# Altium schematic sheet [9] I2C.SchDoc("[9] I2C")
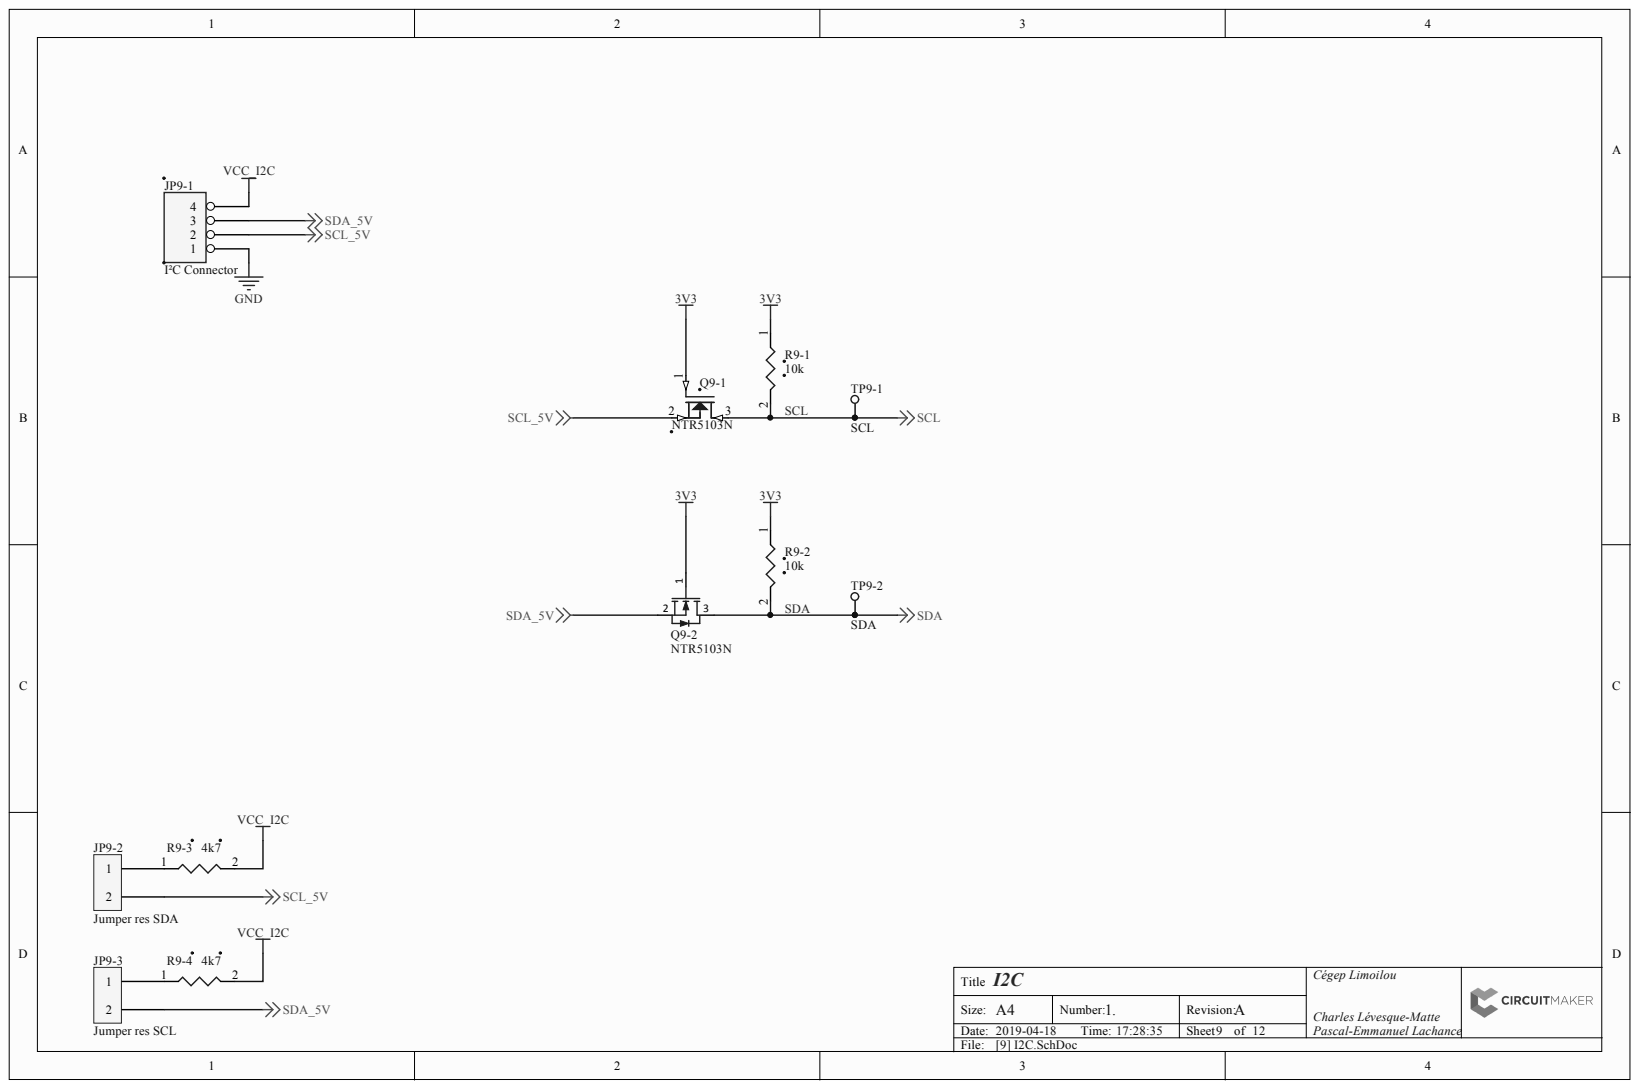
[diagram p0: [9] I2C.SchDoc]

## Parts
JP9-1  I²C Connector  [PCB-kfkyk8r8t2q4xz9myqo9-1]
JP9-2  Jumper res SDA  [PCB-f5rpw3ur0eefzv9pxaxf-1]
JP9-3  Jumper res SCL  [PCB-f5rpw3ur0eefzv9pxaxf-1]
Q9-1  NTR5103N  [PCB-zphymqsti4y0133kiaza-1]
Q9-2  NTR5103N  [PCB-4trtqjanqmpdw865ivvf-1]
R9-1  10k  [PCB-cq2rvmn74vesaj8wmyk0-1]
R9-2  10k  [PCB-cq2rvmn74vesaj8wmyk0-1]
R9-3  4k7  [PCB-g8ucq7vw1s2sdhfr4zem-1]
R9-4  4k7  [PCB-g8ucq7vw1s2sdhfr4zem-1]
TP9-1  SCL  [PCB-a7rpxwhzqdkz91v608la-1]
TP9-2  SDA  [PCB-a7rpxwhzqdkz91v608la-1]

## Nets
3V3: Q9-1.1 Q9-2.1 R9-1.1 R9-2.1
GND: JP9-1.1
SCL_5V: JP9-1.2 JP9-2.2 Q9-1.2
SDA_5V: JP9-1.3 JP9-3.2 Q9-2.2
VCC_I2C: JP9-1.4 R9-3.2 R9-4.2
SCL: Q9-1.3 R9-1.2 TP9-1.1
SDA: Q9-2.3 R9-2.2 TP9-2.1
NetJP9-2_1: JP9-2.1 R9-3.1
NetJP9-3_1: JP9-3.1 R9-4.1

## Library data of the parts
JP9-1 = CMP-93ba5acfef094c7d-8: Manufacturer Part Number: 0705530003; Color: Black; Component Kind: Standard; Library Name: CommunityVault; Lifecycle Status: Not Listed by Manufacturer; Mounting Style: Through Hole; OctopartID: 93ba5acfef094c7d; Octopart URL: http://octopart.com/0705530003-molex-8346069; Packaging: Tube; RoHS: Compliant
JP9-2 JP9-3 = CMP-fad931b1c18a251e-1: Single Row 2 Position 2.54 mm Tin Square Male Pins Unshrouded BERGSTIK II Header; Manufacturer Part Number: 68001-402HLF; Lead-Free Status: Lead Free; Number of Contacts: 2; Pin Pitch: 2.54 mm; Component Kind: Standard; Contact Material: Phosphor Bronze; Contact Plating: Tin, Tin over Nickel; Gender: Male; Library Name: FavoritesLibrary; Mounting Style: Through Hole, Vertical; Number of Positions: 2; Number of Rows: 1; OctopartID: fad931b1c18a251e; Octopart URL: https://octopart.com/68001-402hlf-fci-39984693; RoHS: Compliant
Q9-1 = CMP-02f4c92c4c09dd33-5: Manufacturer Part Number: NTR5103NT1G; Lead-Free Status: Lead Free; Polarity: N-Channel; Case/Package: TO-236, SC-59, SOT-23-3; Component Kind: Standard; Library Name: CommunityVault; Lifecycle Status: Active; Mounting Style: Surface Mount; OctopartID: 02f4c92c4c09dd33; Octopart URL: https://octopart.com/ntr5103nt1g-on+semiconductor-47344539; Packaging: Tape & Reel (TR); RoHS: Compliant
Q9-2 = CMP-02f4c92c4c09dd33-6: Small Signal MOSFET 60V 310mA 2.5mOhm Single N-Channel SOT-23 Small Signal MOSFET; Component Kind: Standard; Library Name: CommunityVault
R9-1 R9-2 = CMP-02dfcaf00d3bdd18-3: Manufacturer Part Number: RMCF0603FT10K0; Lead-Free Status: Lead Free; Power Rating: 100 mW; Resistance: 10.0 kΩ; Resistance Tolerance: ±1%; Case/Package: 0603; Component Kind: Standard; Library Name: CommunityVault; Mounting Style: Surface Mount; OctopartID: 02dfcaf00d3bdd18; Octopart URL: http://octopart.com/rmcf0603ft10k0-stackpole+electronics-19212930; Packaging: Tape & Reel (TR); RoHS: Compliant
R9-3 R9-4 = CMP-011ec9310fadded9-4: Manufacturer Part Number: RMCF0603FT4K70; Lead-Free Status: Lead Free; Power Rating: 100 mW; Resistance: 4.70 kΩ; Resistance Tolerance: ±1%; Case/Package: 0603; Component Kind: Standard; Library Name: CommunityVault; Mounting Style: Surface Mount; OctopartID: 011ec9310fadded9; Octopart URL: https://octopart.com/rmcf0603ft4k70-stackpole+electronics-19213269; Packaging: Tape & Reel (TR); RoHS: Compliant
TP9-1 TP9-2 = CMP-5j384tb079h9dvgbp04a-2: Through-hole Testpoint; Component Kind: Standard; Library Name: CommunityVault
